# Altium schematic sheet accessPoint_PHY.SchDoc(accessPoint_PHY)
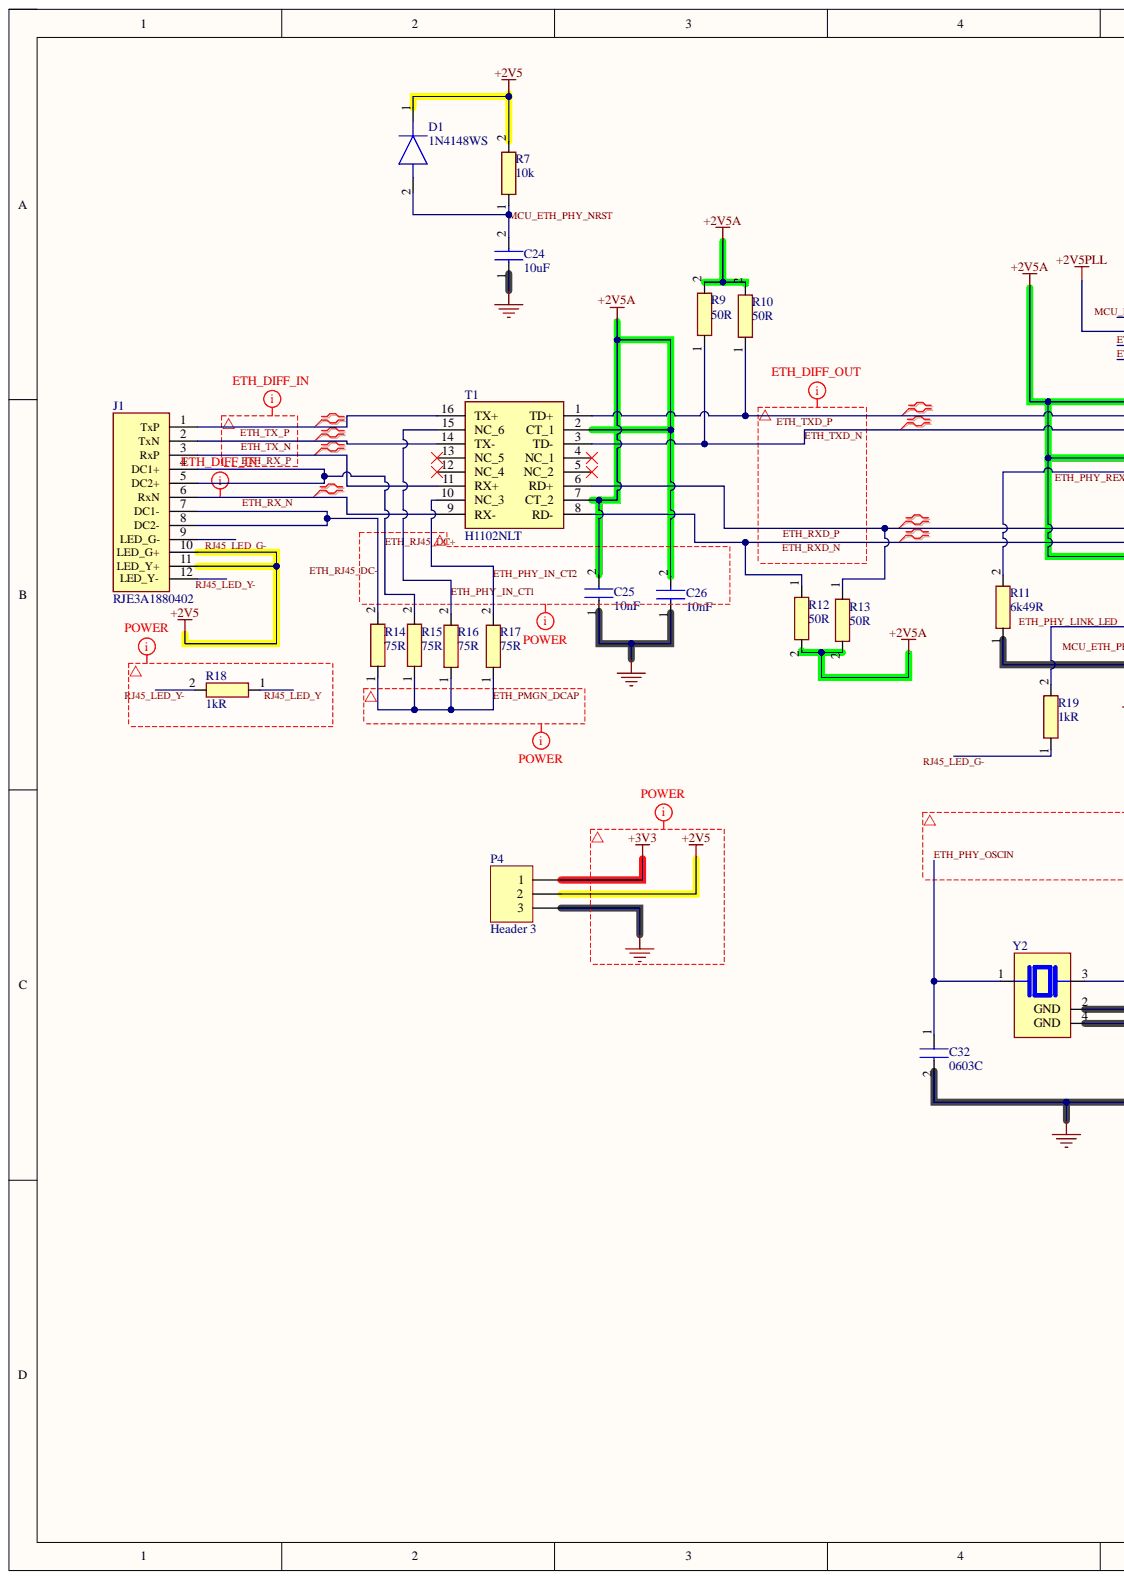
[diagram p0: accessPoint_PHY.SchDoc, left]
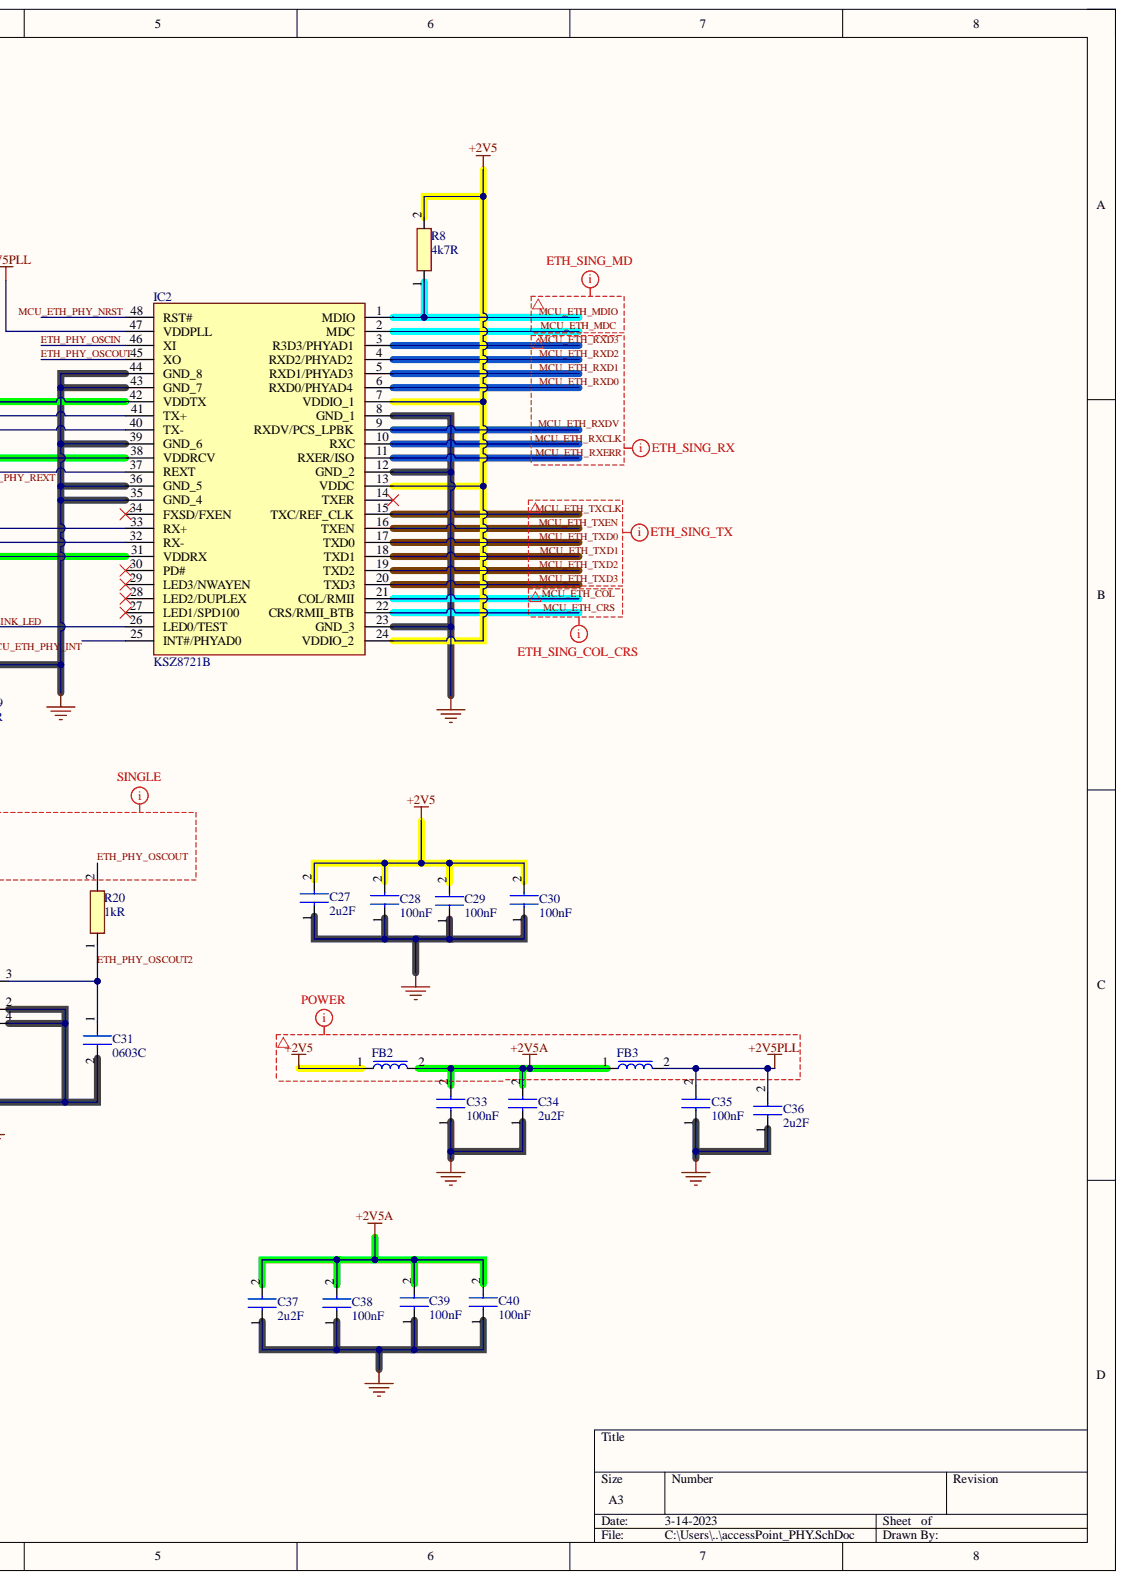
[diagram p1: accessPoint_PHY.SchDoc, right]

## Parts
C24  10uF  [0603]
C25  10nF  [0603]
C26  10nF  [0603]
C27  2u2F  [0603]
C28  100nF  [0603]
C29  100nF  [0603]
C30  100nF  [0603]
C31  0603C  [0603]
C32  0603C  [0603]
C33  100nF  [0603]
C34  2u2F  [0603]
C35  100nF  [0603]
C36  2u2F  [0603]
C37  2u2F  [0603]
C38  100nF  [0603]
C39  100nF  [0603]
C40  100nF  [0603]
D1  1N4148WS  [SODFL2512X90N]
FB2  Ferrite Bead @100R  [BEADC1608X90N]  (value not drawn)
FB3  Ferrite Bead @100R  [BEADC1608X90N]  (value not drawn)
IC2  KSZ8721B  [ETH_PHY_SOP64P1031X279-48N]
J1  RJE3A1880402  [RJE3A1880402]
P4  Header 3  [HDR1X3]
R7  10k  [0603]
R8  4k7R  [0603]
R9  50R  [0603]
R10  50R  [0603]
R11  6k49R  [0603]
R12  50R  [0603]
R13  50R  [0603]
R14  75R  [0603]
R15  75R  [0603]
R16  75R  [0603]
R17  75R  [0603]
R18  1kR  [0603]
R19  1kR  [0603]
R20  1kR  [0603]
T1  H1102NLT  [SOIC127P940X622-16N]
Y2  XTAL_25MHz_18pF  [ABM8G16000MHZB4YT]  (value not drawn)

## Nets
+2V5: C27.2 C28.2 C29.2 C30.2 D1.1 FB2.1 IC2.7(VDDIO_1) IC2.13(VDDC) IC2.24(VDDIO_2) J1.10(LED_G+) J1.11(LED_Y+) P4.2 R7.2 R8.2
+2V5A: C25.2 C26.2 C33.2 C34.2 C37.2 C38.2 C39.2 C40.2 FB2.2 FB3.1 IC2.31(VDDRX) IC2.38(VDDRCV) IC2.42(VDDTX) R9.2 R10.2 R12.2 R13.2 T1.2(CT_1) T1.7(CT_2)
+2V5PLL: C35.2 C36.2 FB3.2 IC2.47(VDDPLL)
+3V3: P4.1
GND: C24.1 C25.1 C26.1 C27.1 C28.1 C29.1 C30.1 C31.2 C32.2 C33.1 C34.1 C35.1 C36.1 C37.1 C38.1 C39.1 C40.1 IC2.8(GND_1) IC2.12(GND_2) IC2.23(GND_3) IC2.35(GND_4) IC2.36(GND_5) IC2.39(GND_6) IC2.43(GND_7) IC2.44(GND_8) P4.3 R11.1 Y2.2(GND) Y2.4(GND)
ETH_PHY_IN_CT1: R16.2 T1.15(NC_6)
ETH_PHY_IN_CT2: R17.2 T1.10(NC_3)
ETH_PHY_LINK_LED: IC2.26(LED0/TEST) R19.2
ETH_PHY_OSCIN: C32.1 IC2.46(XI) Y2.1
ETH_PHY_OSCOUT: IC2.45(XO) R20.2
ETH_PHY_OSCOUT2: C31.1 R20.1 Y2.3
ETH_PHY_REXT: IC2.37(REXT) R11.2
ETH_PMGN_DCAP: R14.1 R15.1 R16.1 R17.1
ETH_RJ45_DC+: J1.4(DC1+) J1.5(DC2+) R15.2
ETH_RJ45_DC-: J1.7(DC1-) J1.8(DC2-) R14.2
ETH_RX_N: J1.6(RxN) T1.9(RX-)
ETH_RX_P: J1.3(RxP) T1.11(RX+)
ETH_RXD_N: IC2.32(RX-) R12.1 T1.8(RD-)
ETH_RXD_P: IC2.33(RX+) R13.1 T1.6(RD+)
ETH_TX_N: J1.2(TxN) T1.14(TX-)
ETH_TX_P: J1.1(TxP) T1.16(TX+)
ETH_TXD_N: IC2.40(TX-) R9.1 T1.3(TD-)
ETH_TXD_P: IC2.41(TX+) R10.1 T1.1(TD+)
MCU_ETH_COL: IC2.21(COL/RMII)
MCU_ETH_CRS: IC2.22(CRS/RMII_BTB)
MCU_ETH_MDC: IC2.2(MDC)
MCU_ETH_MDIO: IC2.1(MDIO) R8.1
MCU_ETH_PHY_INT: IC2.25(INT#/PHYAD0)
MCU_ETH_PHY_NRST: C24.2 D1.2 IC2.48(RST#) R7.1
MCU_ETH_RXCLK: IC2.10(RXC)
MCU_ETH_RXD0: IC2.6(RXD0/PHYAD4)
MCU_ETH_RXD1: IC2.5(RXD1/PHYAD3)
MCU_ETH_RXD2: IC2.4(RXD2/PHYAD2)
MCU_ETH_RXD3: IC2.3(R3D3/PHYAD1)
MCU_ETH_RXDV: IC2.9(RXDV/PCS_LPBK)
MCU_ETH_RXERR: IC2.11(RXER/ISO)
MCU_ETH_TXCLK: IC2.15(TXC/REF_CLK)
MCU_ETH_TXD0: IC2.17(TXD0)
MCU_ETH_TXD1: IC2.18(TXD1)
MCU_ETH_TXD2: IC2.19(TXD2)
MCU_ETH_TXD3: IC2.20(TXD3)
MCU_ETH_TXEN: IC2.16(TXEN)
RJ45_LED_G-: J1.9(LED_G-) R19.1
RJ45_LED_Y: R18.1
RJ45_LED_Y-: J1.12(LED_Y-) R18.2

Not connected: IC2 14(TXER) 27(LED1/SPD100) 28(LED2/DUPLEX) 29(LED3/NWAYEN) 30(PD#) 34(FXSD/FXEN); T1 4(NC_1) 5(NC_2) 12(NC_4) 13(NC_5)

## Library data of the parts
C24-C30 C33-C40 = 0603C: Component Kind: Standard; Library Name: accessPoint.SchLib
D1 = 1N4148WS: Diode; Manufacturer_Name: onsemi; Arrow Part Number: 1N4148WS; Arrow Price/Stock: https://www.arrow.com/en/products/1n4148ws/on-semiconductor?region=europe; Component Kind: Standard; Height: 0.9mm; Manufacturer_Part_Number: 1N4148WS; Mouser Part Number: 512-1N4148WS; Mouser Price/Stock: https://www.mouser.co.uk/ProductDetail/onsemi-Fairchild/1N4148WS?qs=2%2FYqgE%252BHg%252BKBrKZlAsMLhw%3D%3D; Library Name: accessPoint.SchLib
FB2 FB3 = Ferrite Bead @100R: Ferrite Bead; Manufacturer_Name: KEMET; Manufacturer_Part_Number: Z0603C101BPWZT; Arrow Part Number: Z0603C101BPWZT; Arrow Price/Stock: https://www.arrow.com/en/products/z0603c101bpwzt/kemet-corporation; Component Kind: Standard; Datasheet Link: https://api.kemet.com/component-edge/download/datasheet/Z0603C101BPWZT.pdf; Height: 0.9mm; Mouser Part Number: 80-Z0603C101BPWZT; Mouser Price/Stock: https://www.mouser.co.uk/ProductDetail/KEMET/Z0603C101BPWZT?qs=BYlwlsDsB%2FqttfRwYpxQxg%3D%3D; Library Name: accessPoint.SchLib
IC2 = KSZ8721B: Integrated Circuit; Manufacturer_Name: Microchip; Arrow Part Number: KSZ8721B; Arrow Price/Stock: https://www.arrow.com/en/products/ksz8721b/microchip-technology; Component Kind: Standard; Datasheet Link: https://componentsearchengine.com/Datasheets/2/KSZ8721B.pdf; Height: 2.794mm; Manufacturer_Part_Number: KSZ8721B; Library Name: accessPoint.SchLib
J1 = RJE3A1880402: Connector; Manufacturer_Name: Amphenol; Component Kind: Standard; Datasheet Link: https://cdn.amphenol-cs.com/media/wysiwyg/files/drawing/p-rje3a-188-0x02.pdf; Height: 13.45mm; Manufacturer_Part_Number: RJE3A1880402; Mouser Part Number: 523-RJE3A1880402; Mouser Price/Stock: https://www.mouser.co.uk/ProductDetail/Amphenol-Commercial-Products/RJE3A1880402?qs=A6eO%252BMLsxmR2xBkF5o8M4A%3D%3D; Library Name: accessPoint.SchLib
P4 = Header 3: Header, 3-Pin; Component Kind: Standard; LatestRevisionDate: 17-Jul-2002; LatestRevisionNote: Re-released for DXP Platform.; Library Name: Miscellaneous Connectors.IntLib; Signal Integrity: Connector; Publisher: Altium Limited
R7-R20 = 0603R: Component Kind: Standard; Library Name: accessPoint.SchLib
T1 = H1102NLT: Transformer; Manufacturer_Name: Pulse; Arrow Part Number: H1102NLT; Arrow Price/Stock: https://www.arrow.com/en/products/h1102nlt/pulse-electronics-corporation?region=europe; Component Kind: Standard; Datasheet Link: https://productfinder.pulseeng.com/products/datasheets/H1102NL.pdf; Height: 6.22mm; Manufacturer_Part_Number: H1102NLT; Mouser Part Number: 673-H1102NLT; Mouser Price/Stock: https://www.mouser.co.uk/ProductDetail/Pulse-Electronics/H1102NLT?qs=EKu32SdDin8JhhqpR7K10Q%3D%3D; Library Name: accessPoint.SchLib
Y2 = XTAL_25MHz_18pF: ABM10W-16.0000MHZ-4-D1X-T3; MF: {'manufacturer': u'Abracon LLC'}; Availability: Unavailable; Component Kind: Standard; MP: ABM10W-16.0000MHZ-4-D1X-T3; Package: None; Price: None; Library Name: accessPoint.SchLib
C31 C32 = 0603C: Component Kind: Standard; Library Name: accessPoint.SchLib
